# Altium schematic sheet 06_ANALOG_MEASUREMENT.SchDoc(06_ANALOG_MEASUREMENT)
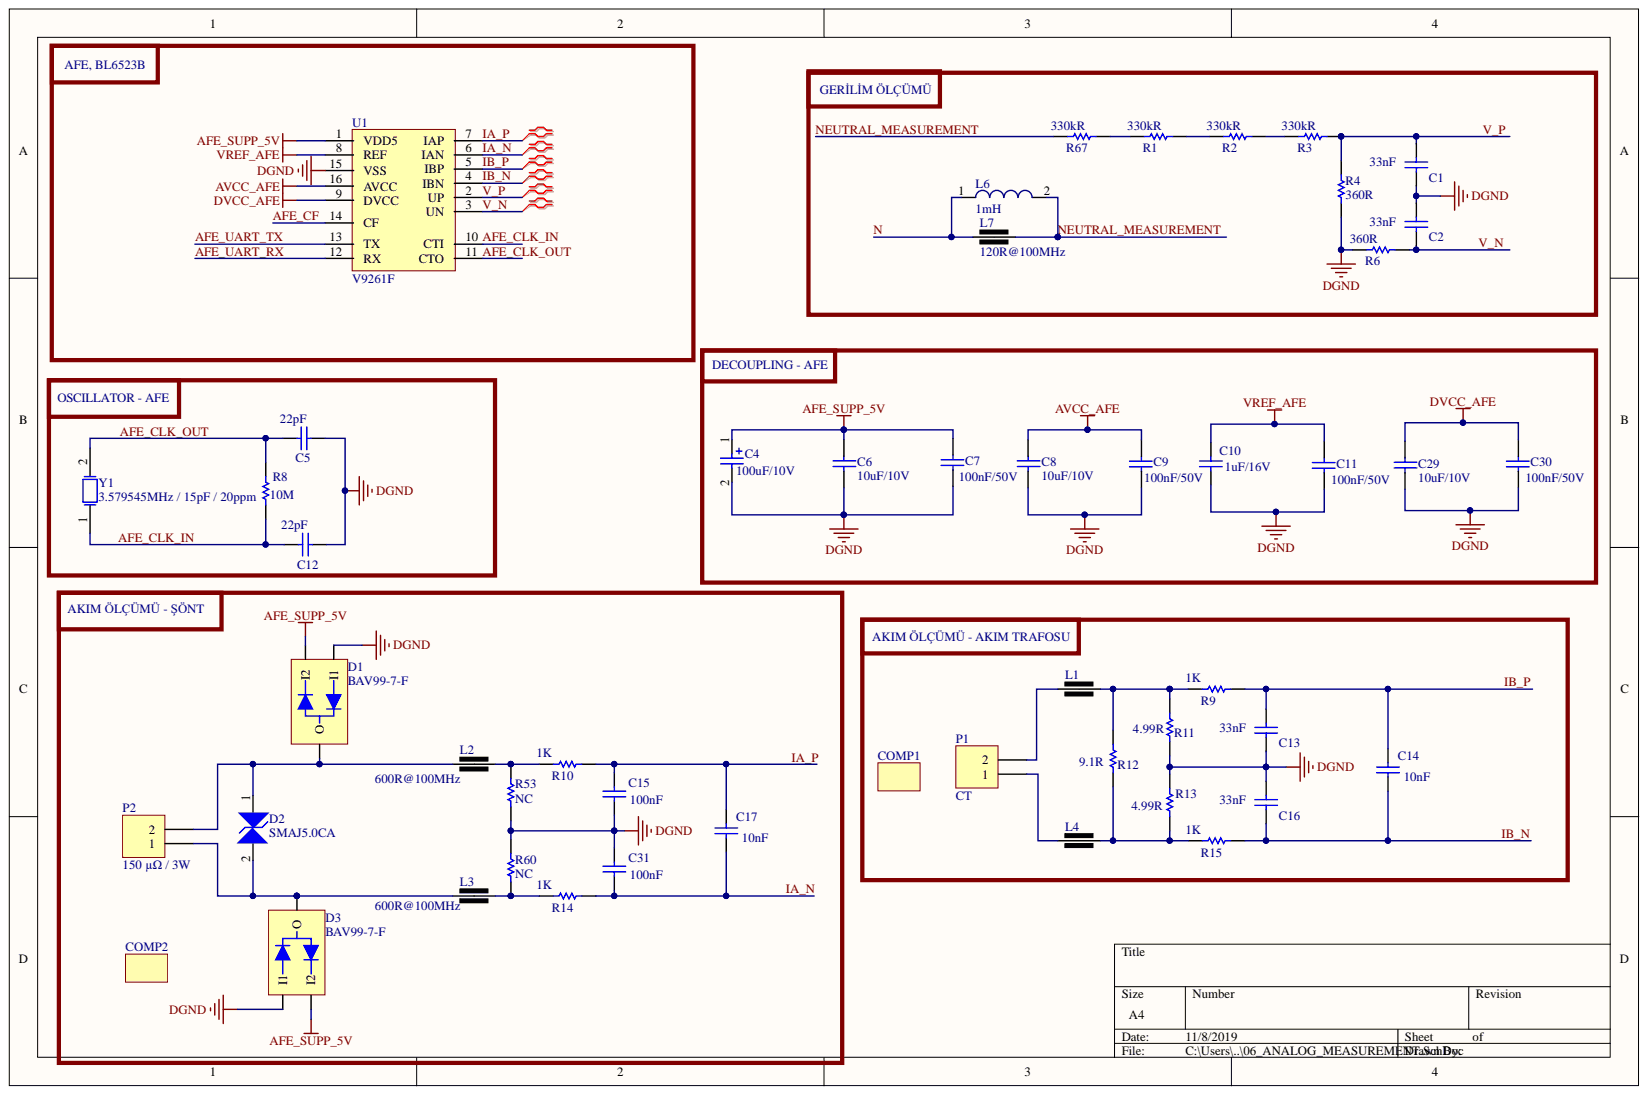
[diagram p0: 06_ANALOG_MEASUREMENT.SchDoc]

## Parts
C1  Capacitance: 33nF  [CAP_0603_V1]  (hidden comment: CAP_SMD)
C2  Capacitance: 33nF  [CAP_0603_V1]  (hidden comment: CAP_SMD)
C4  100uF/10V  [CAP_ALU_ELC_RADIAL_D06.3_H11.0_P1-02.5_P2-03.5]
C5  Capacitance: 22pF  [CAP_0603_V1]  (hidden comment: CAP_SMD)
C6  10uF/10V  [CAP_0603_V1]
C7  100nF/50V  [CAP_0603_V1]
C8  10uF/10V  [CAP_0603_V1]
C9  100nF/50V  [CAP_0603_V1]
C10  Capacitance: 1uF/16V  [CAP_0603_V1]  (hidden comment: CAP_SMD)
C11  100nF/50V  [CAP_0603_V1]
C12  Capacitance: 22pF  [CAP_0603_V1]  (hidden comment: CAP_SMD)
C13  Capacitance: 33nF  [CAP_0603_V1]  (hidden comment: CAP_SMD)
C14  Capacitance: 10nF  [CAP_0603_V1]  (hidden comment: CAP_SMD)
C15  Capacitance: 100nF  [CAP_0603_V1]  (hidden comment: CAP_SMD)
C16  Capacitance: 33nF  [CAP_0603_V1]  (hidden comment: CAP_SMD)
C17  Capacitance: 10nF  [CAP_0603_V1]  (hidden comment: CAP_SMD)
C29  10uF/10V  [CAP_0603_V1]
C30  100nF/50V  [CAP_0603_V1]
C31  Capacitance: 100nF  [CAP_0603_V1]  (hidden comment: CAP_SMD)
COMP1  CT  (value not drawn)
COMP2  CT  (value not drawn)
D1  BAV99-7-F  [SOT-23]
D2  SMAJ5.0CA  [SMA(DO214-AC)]
D3  BAV99-7-F  [SOT-23]
L1  FERRITE BEAD  [FERRITE-BEAD_0603_V1]  (value not drawn)
L2  004_Value: 600R@100MHz  [FERRITE-BEAD_0603_V1]  (hidden comment: FERRITE BEAD)
L3  004_Value: 600R@100MHz  [FERRITE-BEAD_0603_V1]  (hidden comment: FERRITE BEAD)
L4  FERRITE BEAD  [FERRITE-BEAD_0603_V1]  (value not drawn)
L6  1mH  [74404054102]
L7  value 120R@100MHz  [FERRITE-BEAD_1206_V1]  (hidden comment: FBEAD)
P1  004_Value: CT  [HEADER_1X2_V1]  (hidden comment: HEADER_1X2)
P2  004_Value: 150 µΩ / 3W  [HEADER_1X2_V1]  (hidden comment: HEADER_1X2)
R1  Resistance: 330kR  [RES_1206_V1]  (hidden comment: RES_SMD)
R2  Resistance: 330kR  [RES_1206_V1]  (hidden comment: RES_SMD)
R3  Resistance: 330kR  [RES_1206_V1]  (hidden comment: RES_SMD)
R4  Resistance: 360R  [RES_0603_V1]  (hidden comment: RES_SMD)
R6  Resistance: 360R  [RES_0603_V1]  (hidden comment: RES_SMD)
R8  Resistance: 10M  [RES_0603_V1]  (hidden comment: RES_SMD)
R9  Resistance: 1K  [RES_0603_V1]  (hidden comment: RES_SMD)
R10  Resistance: 1K  [RES_0603_V1]  (hidden comment: RES_SMD)
R11  Resistance: 4.99R  [RES_0603_V1]  (hidden comment: RES_SMD)
R12  Resistance: 9.1R  [RES_0603_V1]  (hidden comment: RES_SMD)
R13  Resistance: 4.99R  [RES_0603_V1]  (hidden comment: RES_SMD)
R14  Resistance: 1K  [RES_0603_V1]  (hidden comment: RES_SMD)
R15  Resistance: 1K  [RES_0603_V1]  (hidden comment: RES_SMD)
R53  value NC  [RES_0603_V1]  (hidden comment: RES_SMD)
R60  value NC  [RES_0603_V1]  (hidden comment: RES_SMD)
R67  Resistance: 330kR  [RES_1206_V1]  (hidden comment: RES_SMD)
U1  V9261F  [SOP-16_V1]
Y1  Xtal  [XTAL_HC49_THT - BOTTOM_PAD - TOP_PLACE]  (value not drawn)

## Nets
AFE_SUPP_5V: C4.1 C6.1 C7.2 D1.2 D3.2 U1.1(VDD5)
AVCC_AFE: C8.1 C9.2 U1.16(AVCC)
DGND: C1.1 C2.2 C4.2 C5.2 C6.2 C7.1 C8.2 C9.1 C10.2 C11.1 C12.2 C13.1 C15.1 C16.2 C29.2 C30.1 C31.2 D1.1 D3.1 R4.1 R6.1 R11.1 R13.2 R53.2 R60.1 U1.15(VSS)
DVCC_AFE: C29.1 C30.2 U1.9(DVCC)
VREF_AFE: C10.1 C11.2 U1.8(REF)
AFE_CF: U1.14(CF)
AFE_CLK_IN: C12.1 R8.1 U1.10(CTI) Y1.1
AFE_CLK_OUT: C5.1 R8.2 U1.11(CTO) Y1.2
AFE_UART_RX: U1.12(RX)
AFE_UART_TX: U1.13(TX)
IA_N: C17.1 C31.1 R14.2 U1.6(IAN)
IA_P: C15.2 C17.2 R10.2 U1.7(IAP)
IB_N: C14.1 C16.1 R15.2 U1.4(IBN)
IB_P: C13.2 C14.2 R9.2 U1.5(IBP)
N: L6.1 L7.2
NEUTRAL_MEASUREMENT: L6.2 L7.1 R67.1
V_N: C2.1 R6.2 U1.3(UN)
V_P: C1.2 R3.2 R4.2 U1.2(UP)
NetD1_3: D1.3 D2.1 L2.1 P2.2
NetD2_2: D2.2 D3.3 L3.1 P2.1
NetL1_1: L1.1 P1.2
NetL1_2: L1.2 R9.1 R11.2 R12.2
NetL2_2: L2.2 R10.1 R53.1
NetL3_2: L3.2 R14.1 R60.2
NetL4_1: L4.1 P1.1
NetL4_2: L4.2 R12.1 R13.1 R15.1
NetR1_1: R1.1 R67.2
NetR1_2: R1.2 R2.1
NetR2_2: R2.2 R3.1

## Library data of the parts
C1 C2 C13 C16 = CAP_SMD: 017_Manufacturer-1 PN: CL10B333KB8SFNC; 016_Manufacturer-1: Samsung; Voltage Rating: 50V; Tolerance: 10%; Parameter Name: Tape and Reel; Dielectric: X7R; 006_SMT/THT: Surface Mount; 001_Description: CAP CER 0.033UF 50V X7R 0603; Width: 0.031inch; Thickness: 0.035inch; Supplier Part Number 1: 1276-2042-2-ND; Supplier 1: Digi-Key; Stock 1: 0; Radiation Hardening: No; Package Quantity: 1; Min Operating Temperature: -55°C; Max Operating Temperature: 125°C; Library Name: ElectricMeter.SchLib; Length: 1.6mm; Lead Free: Lead Free; Height: 0.8mm; Features: Soft Termination; Depth: 0.8mm; ComponentLink1URL: https://media.digikey.com/pdf/Data%20Sheets/Samsung%20PDFs/CL_Series_MLCC_ds.pdf?src-supplier=Digi-Key; ComponentLink1Description: https://media.digikey.com/pdf/Data%20Sheets/Samsung%20PDFs/CL_Series_MLCC_ds.pdf?src-supplier=Digi-Key; Component Kind: Standard; Case Code (Metric): 1608; Case Code (Imperial): 0603; Case/Package: 0603
C4 = GF1A101KC110B25CE: Capacitor, Electrolytic, 100uF, 10V, 5x11mm, P2.5mm, 2000H, 105°C; 016_Manufacturer-1: Chang; Value: 100uF/10V; Library Name: ElectricMeter.SchLib; Component Kind: Standard; 017_Manufacturer-1 PN: GF1A101KC110B25CE; 001_Designation: Capacitor
C5 C12 = CAP_SMD: 048_Manufacturer-2 PN: CL10C220JB8NNNC; 047_Manufacturer-2: Samsung; 017_Manufacturer-1 PN: CL10C220FB8NNNC; Voltage Rating (DC): 50V; Tolerance: 1%; Parameter Name: Tape and Reel; Dielectric: C0G; 006_SMT/THT: Surface Mount; 001_Description: Cap Ceramic 22pF 50V C0G 1% SMD 0603 125C Paper T/R; Width: 0.031inch; Voltage Rating: 50V; Thickness: 0.035inch; Supplier Part Number 1: CL10C220FB8NNNC; Supplier 1: Verical; Stock 1: 0; Radiation Hardening: No; Package Quantity: 1; Min Operating Temperature: -55°C; Max Operating Temperature: 125°C; Library Name: ElectricMeter.SchLib; Length: 1.6mm; Lead Free: Lead Free; Height: 0.8mm; Depth: 0.8mm; ComponentLink1URL: https://www.verical.com/server-webapp/html/getDatasheet?partID=641059&src-supplier=Verical; ComponentLink1Description: https://www.verical.com/server-webapp/html/getDatasheet?partID=641059&src-supplier=Verical; Component Kind: Standard; Case Code (Metric): 1608; Case Code (Imperial): 0603; Case/Package: 0603; 016_Manufacturer-1: Samsung
C6 C8 C29 = GRM188R61A106KE69D: 10µF ±10% 10V Ceramic Capacitor X5R 0603 (1608 Metric); 016_Manufacturer-1: Murata; Parameter Name: Tape and Reel; Capacitance: 10uF; Width: 0.031inch; Voltage Rating (DC): 10V; Voltage Rating: 10V; Voltage: 10V; Tolerance: 10%; Thickness: 0.037inch; Supplier Part Number 1: GRM188R61A106KE69D; Supplier 1: Arrow; Stock 1: 2410; REACH SVHC: No SVHC; Pricing 1: 1=0.0335 (USD); Mount: Surface Mount; Min Operating Temperature: -55°C; Max Operating Temperature: 85°C; Library Name: ElectricMeter.SchLib; Length: 1.6mm; Lead Free: Lead Free; Height: 0.8mm; Dielectric: X5R; Depth: 0.8mm; Component Kind: Standard; Case Code (Metric): 1608; Case Code (Imperial): 0603; Case/Package: 0603; 017_Manufacturer-1 PN: GRM188R61A106KE69D
C7 C9 = CL10B104KB8NNNC: MLCC; 100nF; 50V; X7R; ±10%; SMD; 0603; -55÷125°C; 016_Manufacturer-1: Samsung; Parameter Name: Tape and Reel; Material: Ceramic; 006_SMT/THT: Surface Mount; 001_Description: Capacitor: ceramic; MLCC; 100nF; 50V; X7R; ±10%; SMD; 0603; -55÷125°C; Width: 0.031inch; Voltage Rating (DC): 50V; Voltage Rating: 50V; Voltage: 50V; Tolerance: 10%; Thickness: 0.035inch; Supplier Part Number 1: CL10B104KB8NNNC; Supplier 1: Perfect Parts; Stock 1: 0; Radiation Hardening: No; Package Quantity: 1; Min Operating Temperature: -55°C; Max Operating Temperature: 125°C; Library Name: ElectricMeter.SchLib; Length: 1.6mm; Lead Free: Lead Free; Height: 0.8mm; Dielectric: X7R; Depth: 0.8mm; Contact Plating: Tin; ComponentLink3URL: https://produktinfo.conrad.com/datenblaetter/450000-474999/456651-da-01-en-VS_KONDENSATOR_0605.pdf?src-supplier=Conrad; ComponentLink3Description: https://produktinfo.conrad.com/datenblaetter/450000-474999/456651-da-01-en-VS_KONDENSATOR_0605.pdf?src-supplier=Conrad; ComponentLink2URL: https://www.verical.com/server-webapp/html/getDatasheet?partID=89097&src-supplier=Verical; ComponentLink2Description: https://www.verical.com/server-webapp/html/getDatasheet?partID=89097&src-supplier=Verical; ComponentLink1URL: http://www.samsungsem.com/kr/support/product-search/mlcc/CL10B104KB8NNNC.jsp?src-supplier=Digi-Key; ComponentLink1Description: http://www.samsungsem.com/kr/support/product-search/mlcc/CL10B104KB8NNNC.jsp?src-supplier=Digi-Key; Component Kind: Standard; Case Code (Metric): 1608; Case Code (Imperial): 0603; Case/Package: 0603; Capacitance: 100nF; 017_Manufacturer-1 PN: CL10B104KB8NNNC
C10 = CAP_SMD: Cap Ceramic 1uF 16V X7R 10% SMD 0603 125C Paper T/R; 017_Manufacturer-1 PN 1: GRM188R71C105KE15D; 016_Manufacturer-1 1: Murata; Width: 0.8mm; Weight: 0.000222oz; Voltage Rating (DC): 16V; Voltage Rating: 16V; Voltage: 16V; Tolerance: 10%; Thickness: 0.035inch; Termination: SMD/SMT; Supplier Part Number 1: 2688520; Supplier 1: Farnell; Stock 1: 52000; RoHS: Yes; Pricing 1: 4000=0.01660, 20000=0.01060 (EUR); Packaging: Tape and Reel; Package Quantity: 4000; Mount: Surface Mount; Min Operating Temperature: -55°C; Max Operating Temperature: 125°C; Material: Ceramic; Library Name: ElectricMeter.SchLib; Length: 1.6mm; Lead Free: Lead Free; Height: 0.8mm; Dielectric Material: Ceramic; Dielectric: X7R; Depth: 0.8mm; Composition: Ceramic; ComponentLink14URL: https://search.murata.co.jp/Ceramy/image/img/A01X/G101/ENG/GRM188R71C105KE15-01.pdf?src-supplier=Digi-Key; ComponentLink14Description: https://search.murata.co.jp/Ceramy/image/img/A01X/G101/ENG/GRM188R71C105KE15-01.pdf?src-supplier=Digi-Key; ComponentLink13URL: http://search.murata.co.jp/Ceramy/image/img/A01X/G101/ENG/GRM188R71C105KE15-01.pdf?src-supplier=Digi-Key; ComponentLink13Description: http://search.murata.co.jp/Ceramy/image/img/A01X/G101/ENG/GRM188R71C105KE15-01.pdf?src-supplier=Digi-Key; ComponentLink12URL: http://www.mouser.com/ds/2/281/c02e-2905.pdf?src-supplier=Mouser; ComponentLink12Description: http://www.mouser.com/ds/2/281/c02e-2905.pdf?src-supplier=Mouser; ComponentLink11URL: http://uk.rs-online.com/webdocs/144c/0900766b8144c145.pdf?src-supplier=RSComponents; ComponentLink11Description: http://uk.rs-online.com/webdocs/144c/0900766b8144c145.pdf?src-supplier=RSComponents; ComponentLink10URL: http://www.farnell.com/datasheets/2189125.pdf?src-supplier=Farnell; ComponentLink10Description: http://www.farnell.com/datasheets/2189125.pdf?src-supplier=Farnell; ComponentLink9URL: http://components.arrow.com/part/dataview/0323_06704716?src-supplier=Arrow+Europe; ComponentLink9Description: http://components.arrow.com/part/dataview/0323_06704716?src-supplier=Arrow+Europe; ComponentLink8URL: https://datasheet.ciiva.com/16214/l0005e-16214735.pdf?src-supplier=Future+Electronics; ComponentLink8Description: https://datasheet.ciiva.com/16214/l0005e-16214735.pdf?src-supplier=Future+Electronics; ComponentLink7URL: https://datasheet.ciiva.com/138/partnumbering-e-01-138348.pdf?src-supplier=Digi-Key; ComponentLink7Description: https://datasheet.ciiva.com/138/partnumbering-e-01-138348.pdf?src-supplier=Digi-Key; ComponentLink6URL: https://datasheet.ciiva.com/138/partnumbering-e-01-138347.pdf?src-supplier=Digi-Key; ComponentLink6Description: https://datasheet.ciiva.com/138/partnumbering-e-01-138347.pdf?src-supplier=Digi-Key; ComponentLink5URL: https://datasheet.ciiva.com/138/partnumbering-e-01-138346.pdf?src-supplier=Digi-Key; ComponentLink5Description: https://datasheet.ciiva.com/138/partnumbering-e-01-138346.pdf?src-supplier=Digi-Key; ComponentLink4URL: https://datasheet.ciiva.com/7937/1747451-7937637.pdf?src-supplier=Element14; ComponentLink4Description: https://datasheet.ciiva.com/7937/1747451-7937637.pdf?src-supplier=Element14; ComponentLink3URL: https://datasheet.ciiva.com/29199/454501-da-01-en-vs-kondensator-0603-29199592.pdf?src-supplier=Conrad; ComponentLink3Description: https://datasheet.ciiva.com/29199/454501-da-01-en-vs-kondensator-0603-29199592.pdf?src-supplier=Conrad; ComponentLink2URL: https://datasheet.ciiva.com/3779/11136grm188r71c105ka1223-3779454.pdf?src-supplier=Arrow; ComponentLink2Description: https://datasheet.ciiva.com/3779/11136grm188r71c105ka1223-3779454.pdf?src-supplier=Arrow; ComponentLink1URL: https://datasheet.ciiva.com/22703/1747451-22703332.pdf?src-supplier=Element14; ComponentLink1Description: https://datasheet.ciiva.com/22703/1747451-22703332.pdf?src-supplier=Element14; Component Kind: Standard; Case Code (Metric): 1608; Case Code (Imperial): 0603; Case/Package: 0603
C11 C30 = CL10B104KB8NNNC: MLCC; 100nF; 50V; X7R; ±10%; SMD; 0603; -55÷125°C; 016_Manufacturer-1: Samsung; Parameter Name: Tape and Reel; Material: Ceramic; Width: 0.031inch; Voltage Rating (DC): 50V; Voltage Rating: 50V; Voltage: 50V; Tolerance: 10%; Thickness: 0.035inch; Supplier Part Number 1: CL10B104KB8NNNC; Supplier 1: Arrow; Stock 1: 16987458; Radiation Hardening: No; Pricing 1: 4000=0.0047, 8000=0.0043, 12000=0.0032, 1=0.0352, 10=0.0258, 25=0.0233, 100=0.02, 250=0.018 (USD); Package Quantity: 1; Mount: Surface Mount; Min Operating Temperature: -55°C; Max Operating Temperature: 125°C; Library Name: ElectricMeter.SchLib; Length: 1.6mm; Lead Free: Lead Free; Height: 0.8mm; Dielectric: X7R; Depth: 0.8mm; Contact Plating: Tin; ComponentLink3URL: https://produktinfo.conrad.com/datenblaetter/450000-474999/456651-da-01-en-VS_KONDENSATOR_0605.pdf?src-supplier=Conrad; ComponentLink3Description: https://produktinfo.conrad.com/datenblaetter/450000-474999/456651-da-01-en-VS_KONDENSATOR_0605.pdf?src-supplier=Conrad; ComponentLink2URL: https://www.verical.com/server-webapp/html/getDatasheet?partID=89097&src-supplier=Verical; ComponentLink2Description: https://www.verical.com/server-webapp/html/getDatasheet?partID=89097&src-supplier=Verical; ComponentLink1URL: http://www.samsungsem.com/kr/support/product-search/mlcc/CL10B104KB8NNNC.jsp?src-supplier=Digi-Key; ComponentLink1Description: http://www.samsungsem.com/kr/support/product-search/mlcc/CL10B104KB8NNNC.jsp?src-supplier=Digi-Key; Component Kind: Standard; Case Code (Metric): 1608; Case Code (Imperial): 0603; Case/Package: 0603; Capacitance: 100nF; 017_Manufacturer-1 PN: CL10B104KB8NNNC
C14 C17 = CAP_SMD: 017_Manufacturer-1 PN 1: CL10B103KB8NNNC; 016_Manufacturer-1 1: Samsung; Voltage Rating (DC): 50V; Tolerance: 10%; Material: Ceramic; Dielectric: X7R; Width: 0.031inch; Voltage Rating: 50V; Voltage: 50V; Thickness: 0.035inch; Supplier Part Number 1: 1276-1009-1-ND; Supplier 1: Digi-Key; Stock 1: 4980974; RoHS: Yes; Radiation Hardening: No; Pricing 1: 1=0.10000, 10=0.03900, 100=0.01720, 500=0.01232, 1000=0.00968 (USD); Packaging: Tape and Reel; Package Quantity: 1; Mount: Surface Mount; Min Operating Temperature: -55°C; Max Operating Temperature: 125°C; Library Name: ElectricMeter.SchLib; Length: 1.6mm; Lead Free: Lead Free; Height: 0.8mm; Depth: 0.8mm; Contact Plating: Tin; ComponentLink3URL: https://datasheet.ciiva.com/20173/71-0315-20173334.pdf?src-supplier=Rapid+Electronics; ComponentLink3Description: https://datasheet.ciiva.com/20173/71-0315-20173334.pdf?src-supplier=Rapid+Electronics; ComponentLink2URL: https://datasheet.ciiva.com/29198/457455-da-01-en-vs-kondensator-0603-29198644.pdf?src-supplier=Conrad; ComponentLink2Description: https://datasheet.ciiva.com/29198/457455-da-01-en-vs-kondensator-0603-29198644.pdf?src-supplier=Conrad; ComponentLink1URL: https://datasheet.ciiva.com/15195/getdatasheetpartid-357415-15195721.pdf?src-supplier=Verical; ComponentLink1Description: https://datasheet.ciiva.com/15195/getdatasheetpartid-357415-15195721.pdf?src-supplier=Verical; Component Kind: Standard; Case Code (Metric): 1608; Case Code (Imperial): 0603; Case/Package: 0603
C15 C31 = CAP_SMD: 017_Manufacturer-1 PN 1: CL10B104KB8NNNC; 016_Manufacturer-1 1: Samsung; Voltage Rating (DC): 50V; Tolerance: 10%; Material: Ceramic; Dielectric: X7R; Width: 0.031inch; Voltage Rating: 50V; Voltage: 50V; Thickness: 0.035inch; Supplier Part Number 1: 1276-1000-2-ND; Supplier 1: Digi-Key; Stock 1: 10908000; RoHS: Yes; Radiation Hardening: No; Pricing 1: 4000=0.00481, 8000=0.00439, 12000=0.00418, 28000=0.00397, 100000=0.00293 (USD); Packaging: Tape and Reel; Package Quantity: 1; Mount: Surface Mount; Min Operating Temperature: -55°C; Max Operating Temperature: 125°C; Library Name: ElectricMeter.SchLib; Length: 1.6mm; Lead Free: Lead Free; Height: 0.8mm; Depth: 0.8mm; Contact Plating: Tin; ComponentLink4URL: https://datasheet.ciiva.com/29198/456651-da-01-en-vs-kondensator-0605-29198839.pdf?src-supplier=Conrad; ComponentLink4Description: https://datasheet.ciiva.com/29198/456651-da-01-en-vs-kondensator-0605-29198839.pdf?src-supplier=Conrad; ComponentLink3URL: https://datasheet.ciiva.com/26838/getdatasheetpartid-89097-26838411.pdf?src-supplier=Verical; ComponentLink3Description: https://datasheet.ciiva.com/26838/getdatasheetpartid-89097-26838411.pdf?src-supplier=Verical; ComponentLink2URL: https://datasheet.ciiva.com/25803/cl10b104kb8nnnc-25803393.pdf?src-supplier=Digi-Key; ComponentLink2Description: https://datasheet.ciiva.com/25803/cl10b104kb8nnnc-25803393.pdf?src-supplier=Digi-Key; ComponentLink1URL: https://media.digikey.com/pdf/Data%20Sheets/Samsung%20PDFs/CL_Series_MLCC_ds.pdf?src-supplier=Digi-Key; ComponentLink1Description: https://media.digikey.com/pdf/Data%20Sheets/Samsung%20PDFs/CL_Series_MLCC_ds.pdf?src-supplier=Digi-Key; Component Kind: Standard; Case Code (Metric): 1608; Case Code (Imperial): 0603; Case/Package: 0603
COMP1 = 00_BOS: Current Transformer Spec: CT_10(100)/4mA; 017_Manufacturer-1 PN: SMT606; 016_Manufacturer-1: Smart Technology; Library Name: SCH_LIB.SCHLIB; Component Kind: Standard
COMP2 = 00_BOS: Mavi renkli şönt kablosu P3'ün 1 nolu pinine, sarı renkli şönt kablosu P3'ün 2 nolu pinine bağlanacak.; 017_Manufacturer-1 PN: SMT606; 016_Manufacturer-1: Smart Technology; Library Name: SCH_LIB.SCHLIB; Component Kind: Standard
D1 D3 = BAV99: Diode, Dual, Switching, BAV99; 016_Manufacturer-1: Diodes; SMT/THT: SMT; Value: BAV99-7-F; Status: MP; Published: 19-JUL-2018; Project: -; Library Name: ElectricMeter.SchLib; Designation: Diode; Component Kind: Standard; 017_Manufacturer-1 PN: BAV99-7-F; Publisher: AS
D2 = SMAJ5.0CA: 016_Manufacturer-1: Littelfuse; Voltage Rating (DC): 5V; Test Current: 10mA; Reverse Breakdown Voltage: 6.4V; Power Line Protection: No; Power Dissipation: 400W; Polarity: Bidirectional; Peak Pulse Current: 43.5A; Parameter Name: Tape and Reel; Number of Elements: 1; Max Reverse Leakage Current: 800uA; Max Breakdown Voltage: 7V; Leakage Current: 1.6mA; Element Configuration: Single; Clamping Voltage: 9.2V; Capacitance: 1.05nF; 006_SMT/THT: Surface Mount; 001_Description: TVS DIODE 5V 9.2V DO214AC; Width: 2.79mm; Termination: SMD/SMT; Supplier Part Number 1: 1677591; Supplier 1: Farnell; Stock 1: 0; Reverse Standoff Voltage: 5V; REACH SVHC: No SVHC; Radiation Hardening: No; Peak Pulse Power: 400W; Package Quantity: 5000; Operating Supply Voltage: 5V; Number of Pins: 2; Number of Channels: 1; Number of Bidirectional Channels: 1; Min Operating Temperature: -65°C; Min Breakdown Voltage: 6.4V; Max Surge Current: 43.5A; Max Power Dissipation: 400W; Max Output Current: 43.5A; Max Operating Temperature: 150°C; Library Name: ElectricMeter.SchLib; Length: 4.5mm; Lead Free: Lead Free; Height: 2.087mm; Direction: Bidirectional; Composition: Zener; ComponentLink3URL: http://www.farnell.com/datasheets/607617.pdf?src-supplier=Element14; ComponentLink3Description: http://www.farnell.com/datasheets/607617.pdf?src-supplier=Element14; ComponentLink2URL: http://www.littelfuse.com/~/media/Electronics/Datasheets/TVS_Diodes/Littelfuse_TVS_Diode_SMAJ_Datasheet.pdf.pdf?src-supplier=Future+Electronics; ComponentLink2Description: http://www.littelfuse.com/~/media/Electronics/Datasheets/TVS_Diodes/Littelfuse_TVS_Diode_SMAJ_Datasheet.pdf.pdf?src-supplier=Future+Electronics; ComponentLink1URL: https://www.littelfuse.com/~/media/electronics/datasheets/tvs_diodes/littelfuse_tvs_diode_smaj_datasheet.pdf.pdf?src-supplier=Digi-Key; ComponentLink1Description: https://www.littelfuse.com/~/media/electronics/datasheets/tvs_diodes/littelfuse_tvs_diode_smaj_datasheet.pdf.pdf?src-supplier=Digi-Key; Component Kind: Standard; Breakdown Voltage: 6.4V; 017_Manufacturer-1 PN: SMAJ5.0CA
L1 L4 = FERRITE BEAD: Inductor, Ferrite Bead, 120R@100Mhz, Power Line, 2A; 017_Manufacturer-1 PN: BLM18PG121SN1D; 016_Manufacturer-1: Murata; Tolerance: 25%; Test Frequency: 100MHz; Shielding: Unshielded; Parameter Name: Tape and Reel; Number of Lines: 1; Material: Steel,Ferrite; Impedance: 120R; DC Resistance (DCR): 50mR; 001_Description: MURATA - BLM18PG121SN1D - FERRITE BEAD, 120 OHM, 2A, 0603; Width: 0.8mm; Termination: SMD/SMT; Supplier Part Number 1: 724-1380; Supplier 1: RSComponents; Stock 1: 0; REACH SVHC: No SVHC; Radiation Hardening: No; Package Quantity: 1; Number of Pins: 2; Number of Circuits: 1; Min Operating Temperature: -55°C; Max Operating Temperature: 125°C; Max DC Current: 2A; Max Current Rating: 2A; Library Name: ElectricMeter.SchLib; Length: 1.6mm; Lead Free: Lead Free; Height: 0.8mm; Frequency: 100MHz; Depth: 0.8mm; DC Current: 2A; Current Rating: 2A; Core Material: Ferrite; ComponentLink11URL: http://www.produktinfo.conrad.com/datenblaetter/1100000-1199999/001172297-da-01-en-DROSSEL_0603_120R_1_6X0_8MM_4000ST.pdf?src-supplier=Conrad; ComponentLink11Description: http://www.produktinfo.conrad.com/datenblaetter/1100000-1199999/001172297-da-01-en-DROSSEL_0603_120R_1_6X0_8MM_4000ST.pdf?src-supplier=Conrad; ComponentLink10URL: http://www.produktinfo.conrad.com/datenblaetter/425000-449999/442365-da-01-en-CHIP_DROSSEL_0603_120R_2000MA_1_6X0_8MM.pdf?src-supplier=Conrad; ComponentLink10Description: http://www.produktinfo.conrad.com/datenblaetter/425000-449999/442365-da-01-en-CHIP_DROSSEL_0603_120R_2000MA_1_6X0_8MM.pdf?src-supplier=Conrad; ComponentLink9URL: http://www.farnell.com/datasheets/1740433.pdf?src-supplier=Avnet+Europe; ComponentLink9Description: http://www.farnell.com/datasheets/1740433.pdf?src-supplier=Avnet+Europe; ComponentLink8URL: http://www.farnell.com/datasheets/1740433.pdf?src-supplier=Jameco; ComponentLink8Description: http://www.farnell.com/datasheets/1740433.pdf?src-supplier=Jameco; ComponentLink7URL: http://uk.rs-online.com/webdocs/13d6/0900766b813d688c.pdf?src-supplier=RSComponents; ComponentLink7Description: http://uk.rs-online.com/webdocs/13d6/0900766b813d688c.pdf?src-supplier=RSComponents; ComponentLink6URL: http://www.farnell.com/datasheets/1740433.pdf?src-supplier=Distrelec; ComponentLink6Description: http://www.farnell.com/datasheets/1740433.pdf?src-supplier=Distrelec; ComponentLink5URL: http://www.farnell.com/datasheets/1740433.pdf?src-supplier=Arrow; ComponentLink5Description: http://www.farnell.com/datasheets/1740433.pdf?src-supplier=Arrow; ComponentLink4URL: https://www.murata.com/en-us/products/productdata/8796738650142/ENFA0003.pdf?src-supplier=Digi-Key; ComponentLink4Description: https://www.murata.com/en-us/products/productdata/8796738650142/ENFA0003.pdf?src-supplier=Digi-Key; ComponentLink3URL: http://www.farnell.com/datasheets/1740433.pdf?src-supplier=Element14; ComponentLink3Description: http://www.farnell.com/datasheets/1740433.pdf?src-supplier=Element14; ComponentLink2URL: http://www.farnell.com/datasheets/1740433.pdf?src-supplier=Farnell; ComponentLink2Description: http://www.farnell.com/datasheets/1740433.pdf?src-supplier=Farnell; ComponentLink1URL: http://www.farnell.com/datasheets/1740433.pdf?src-supplier=Avnet; ComponentLink1Description: http://www.farnell.com/datasheets/1740433.pdf?src-supplier=Avnet; Component Kind: Standard; Case Code (Metric): 1608; Case Code (Imperial): 0603; Case/Package: 0603
L2 L3 = FERRITE BEAD_600R: Inductor, Ferrite Bead, SMD0603, 600R@100Mhz; 017_Manufacturer-1 PN: BLM18AG601SN1D; 016_Manufacturer-1: Murata; 008_Package: L0603; 007_Status: MP; 006_SMT/THT: SMT; 005_Process: SMD_Mounter; Library Name: ElectricMeter.SchLib; Component Kind: Standard; 003_Remark: -; 001_Designation: Ferrite Bead
L6 = 74404054102: FIXED IND 1MH 200MA 6 OHM SMD; 016_Manufacturer-1: Wurth Electronics; Tolerance: 20%; Test Frequency: 100kHz; Shielding: Shielded; Self Resonant Frequency: 1.9MHz; Saturation Current: 260mA; Parameter Name: Tape and Reel; DC Resistance (DCR): 6R; Width: 0.197inch; Supplier Part Number 1: 8987977; Supplier 1: RSComponents; Stock 1: 0; Mount: Surface Mount; Min Operating Temperature: -40°C; Max Operating Temperature: 125°C; Library Name: ElectricMeter.SchLib; Length: 0.197inch; Inductance: 1mH; Height - Seated (Max): 0.157inch; Current Rating: 200mA; Composition: Wirewound; Component Kind: Standard; Case/Package: Nonstandard; 017_Manufacturer-1 PN: 74404054102
L7 = FBEAD: Inductor, Ferrite Bead, 120R@100Mhz, Power Line, 2A; 017_Manufacturer-1 PN: CIC31P121NE; 016_Manufacturer-1: Samsung; SMT/THT: SMT; Model 4: BM32; Model 3: BM31; Model 2: BM22; Model 1: BM21; Status: OP; Manufacturer PN 2: -; Manufacturer 2: -; Library Name: HW.SchLib; Designation: Inductor; Component Kind: Standard
P1 = HEADER_1X2: 017_Manufacturer-1 PN: CT Header Pins; 016_Manufacturer-1: BAYLAN; 007_Status: MP; 006_SMT/THT: THT; 005_Process: Wave_Solder; 001_Designation: Shunt; Library Name: ElectricMeter.SchLib; Description: HEADER_1X2; Component Kind: Standard; 019_Manufacturer-1 Digi-Key PN: -; 008_Package: -; 003_Remark: -
P2 = HEADER_1X2: 017_Manufacturer-1 PN: 300 µΩ Shunt; 016_Manufacturer-1: Weija; 007_Status: MP; 006_SMT/THT: THT; 005_Process: Wave_Solder; 001_Designation: Shunt; Library Name: ElectricMeter.SchLib; Description: HEADER_1X2; Component Kind: Standard; 019_Manufacturer-1 Digi-Key PN: -; 008_Package: -; 003_Remark: -
R1-R3 R67 = RES_SMD: 017_Manufacturer-1 PN: RC1206FR-07330KL; 016_Manufacturer-1: Yageo; Voltage Rating (DC): 200V; Tolerance: 1%; Temperature Coefficient: 100ppm/°C; Power Rating: 250mW; Parameter Name: Tape and Reel; 001_Description: RES SMD 330K OHM 1% 1/4W 1206; Width: 1.6mm; Voltage Rating: 200V; Termination: SMD/SMT; Supplier Part Number 1: 617-9528; Supplier 1: RSComponents; Stock 1: 0; REACH SVHC: Unknown; Number of Pins: 2; Min Operating Temperature: -55°C; Military Standard: Not; Max Operating Temperature: 155°C; Library Name: ElectricMeter.SchLib; Length: 3.1mm; Lead Free: Lead Free; Height: 0.55mm; Depth: 1.6mm; Composition: Thick Film; ComponentLink4URL: http://www.farnell.com/datasheets/444357.pdf?src-supplier=Element14; ComponentLink4Description: http://www.farnell.com/datasheets/444357.pdf?src-supplier=Element14; ComponentLink3URL: http://documents.rs-components.com/techlib://itc_doclist_GB.htm?stockno=6179528&src-supplier=RSComponents; ComponentLink3Description: http://documents.rs-components.com/techlib://itc_doclist_GB.htm?stockno=6179528&src-supplier=RSComponents; ComponentLink2URL: http://www.farnell.com/datasheets/1686128.pdf?src-supplier=Farnell; ComponentLink2Description: http://www.farnell.com/datasheets/1686128.pdf?src-supplier=Farnell; ComponentLink1URL: http://www.yageo.com/documents/recent/PYu-RC1206_51_RoHS_L-4.pdf?src-supplier=Future+Electronics; ComponentLink1Description: http://www.yageo.com/documents/recent/PYu-RC1206_51_RoHS_L-4.pdf?src-supplier=Future+Electronics; Component Kind: Standard; Case Code (Metric): 3216; Case Code (Imperial): 1206; Case/Package: 1206
R4 R6 = RES_SMD: 017_Manufacturer-1 PN: 0603WAF3600T5E; 016_Manufacturer-1: Royal Electronic Factory; Tolerance: 1%; 001_Description: Resistor: thick film; SMD; 0603; 360R; 0.1W; ±1%; -55÷125°C; Supplier Part Number 1: 0603SAF1001T5E; Supplier 1: Quest; Stock 1: 0; Library Name: ElectricMeter.SchLib; Component Kind: Standard; Case/Package: 0603
R8 = RES_SMD: 017_Manufacturer-1 PN: RC0603FR-0710ML; 016_Manufacturer-1: Yageo; Voltage Rating (DC): 50V; Tolerance: 5%; Temperature Coefficient: 100ppm/°C; Power Rating: 100mW; Parameter Name: Tape and Reel; 001_Description: RES SMD 10M OHM 1% 1/10W 0603; Width: 0.031inch; Voltage Rating: 50V; Termination: SMD/SMT; Supplier Part Number 1: RC0603FR-07 10ML; Supplier 1: Verical; Stock 1: 0; REACH SVHC: Unknown; Package Quantity: 1; Number of Terminations: 2; Number of Pins: 2; Min Operating Temperature: -55°C; Military Standard: Not; Max Power Dissipation: 100mW; Max Operating Temperature: 155°C; Library Name: ElectricMeter.SchLib; Length: 1.6mm; Lead Free: Lead Free; Height: 0.022inch; Features: Moisture Resistant; Depth: 0.8mm; Composition: Thick Film; ComponentLink4URL: http://www.farnell.com/datasheets/1686128.pdf?src-supplier=Farnell; ComponentLink4Description: http://www.farnell.com/datasheets/1686128.pdf?src-supplier=Farnell; ComponentLink3URL: http://www.farnell.com/datasheets/1868787.pdf?src-supplier=Element14; ComponentLink3Description: http://www.farnell.com/datasheets/1868787.pdf?src-supplier=Element14; ComponentLink2URL: http://www.yageo.com/documents/recent/PYu-RC_Group_51_RoHS_L_9.pdf?src-supplier=Digi-Key; ComponentLink2Description: http://www.yageo.com/documents/recent/PYu-RC_Group_51_RoHS_L_9.pdf?src-supplier=Digi-Key; ComponentLink1URL: http://www.yageo.com/documents/recent/PYu-RC_Group_51_RoHS_L_10.pdf?src-supplier=Digi-Key; ComponentLink1Description: http://www.yageo.com/documents/recent/PYu-RC_Group_51_RoHS_L_10.pdf?src-supplier=Digi-Key; Component Kind: Standard; Case Code (Metric): 1608; Case Code (Imperial): 0603; Case/Package: 0603
R9 R10 R14 R15 = RES_SMD: 017_Manufacturer-1 PN: 0603SAF1001T5E; 016_Manufacturer-1: Royal Electronic Factory; Tolerance: 1%; 001_Description: Resistor: thick film; SMD; 0603; 1kΩ; 0.1W; ±1%; -55÷125°C; Supplier Part Number 1: 0603SAF1001T5E; Supplier 1: Quest; Stock 1: 0; Library Name: ElectricMeter.SchLib; Component Kind: Standard; Case/Package: 0603
R11 R13 = RES_SMD: 017_Manufacturer-1 PN: RC0603FR-074R99L; 016_Manufacturer-1: Yageo; Voltage Rating (DC): 50V; Tolerance: 1%; Temperature Coefficient: 200ppm/°C; Power Rating: 100mW; Parameter Name: Tape and Reel; 001_Description: RES SMD 4.99 OHM 1% 1/10W 0603; Width: 0.031inch; Supplier Part Number 1: RC0603FR-074R99L; Supplier 1: Perfect Parts; Stock 1: 0; Package Quantity: 1; Number of Terminations: 2; Min Operating Temperature: -55°C; Military Standard: Not; Max Power Dissipation: 100mW; Max Operating Temperature: 155°C; Library Name: ElectricMeter.SchLib; Length: 0.063inch; Lead Free: Lead Free; Height: 0.022inch; Features: Moisture Resistant; Depth: 0.8mm; Contact Plating: Tin,Matte; Composition: Thick Film; ComponentLink3URL: http://www.yageo.com/documents/recent/PYu-RC_Group_51_RoHS_L_9.pdf?src-supplier=Digi-Key; ComponentLink3Description: http://www.yageo.com/documents/recent/PYu-RC_Group_51_RoHS_L_9.pdf?src-supplier=Digi-Key; ComponentLink2URL: http://www.yageo.com/documents/recent/PYu-RC_Group_51_RoHS_L_10.pdf?src-supplier=Digi-Key; ComponentLink2Description: http://www.yageo.com/documents/recent/PYu-RC_Group_51_RoHS_L_10.pdf?src-supplier=Digi-Key; ComponentLink1URL: http://www.yageo.com/documents/recent/PYu-RC0603_51_RoHS_L_4.pdf?src-supplier=Avnet; ComponentLink1Description: http://www.yageo.com/documents/recent/PYu-RC0603_51_RoHS_L_4.pdf?src-supplier=Avnet; Component Kind: Standard; Case Code (Metric): 1608; Case Code (Imperial): 0603; Case/Package: 0603
R12 = RES_SMD: 017_Manufacturer-1 PN: RC0603FR-079R1L; 016_Manufacturer-1: Yageo; Voltage Rating: 50V; Tolerance: 1%; Temperature Coefficient: 200ppm/°C; Power Rating: 100mW; Parameter Name: Tape and Reel; 006_SMT/THT: Surface Mount; 001_Description: Res Thick Film 0603 9.1 Ohm 1% 0.1W(1/10W) ±200ppm/C Molded SMD T/R; Width: 0.031inch; Termination: SMD/SMT; Supplier Part Number 1: RC0603FR-07 9R1L; Supplier 1: Verical; Stock 1: 0; Radiation Hardening: No; Number of Terminations: 2; Min Operating Temperature: -55°C; Military Standard: Not; Max Power Dissipation: 100mW; Max Operating Temperature: 155°C; Library Name: ElectricMeter.SchLib; Length: 1.6mm; Lead Free: Lead Free; Height: 0.022inch; Features: Moisture Resistant; Depth: 0.8mm; Contact Plating: Tin,Matte; Composition: Thick Film; ComponentLink1URL: http://www.mouser.com/ds/2/447/RC0603-272842.pdf?src-supplier=Mouser; ComponentLink1Description: http://www.mouser.com/ds/2/447/RC0603-272842.pdf?src-supplier=Mouser; Component Kind: Standard; Case Code (Metric): 1608; Case Code (Imperial): 0603; Case/Package: 0603
R53 R60 = RES_SMD: Designation: Resistor; Library Name: BM_COMM_180705.IntLib; Component Kind: Standard
U1 = V9261F: Single-Phase Energy Metering AFE; 016_Manufacturer-1: Vango; Library Name: ElectricMeter.SchLib; ComponentLink1URL: http://www.vangotech.com/int/product.php?areas=0&bigs=10&smalls=15&id=6; ComponentLink1Description: V9261F; Component Kind: Standard; 017_Manufacturer-1 PN: V9261F
Y1 = XTAL_2-PINS: Crystal, HC-49/US, 3.579545MHz, ±20ppm, 15pF; 048_Manufacturer-2 PN: Q 3,579545 SS4-18-30/50-T1-LL= 5-LF; 047_Manufacturer-2: Jauch; 019_Manufacturer-1 Digi-Key PN: AS-3.579545MDHE-B-ND; 017_Manufacturer-1 PN: AS-3.579545MDHE-B; 016_Manufacturer-1: TXC; 008_Package: HC49; 007_Status: MP; 006_SMT/THT: THT; 005_Process: Manuel_Solder; 004_Value: 3.579545MHz / 15pF / 20ppm; 001_Designation: Crystal_Oscillator; Library Name: ElectricMeter.SchLib; Component Kind: Standard; 003_Remark: -
